# Altium schematic sheet TOP.SchDoc
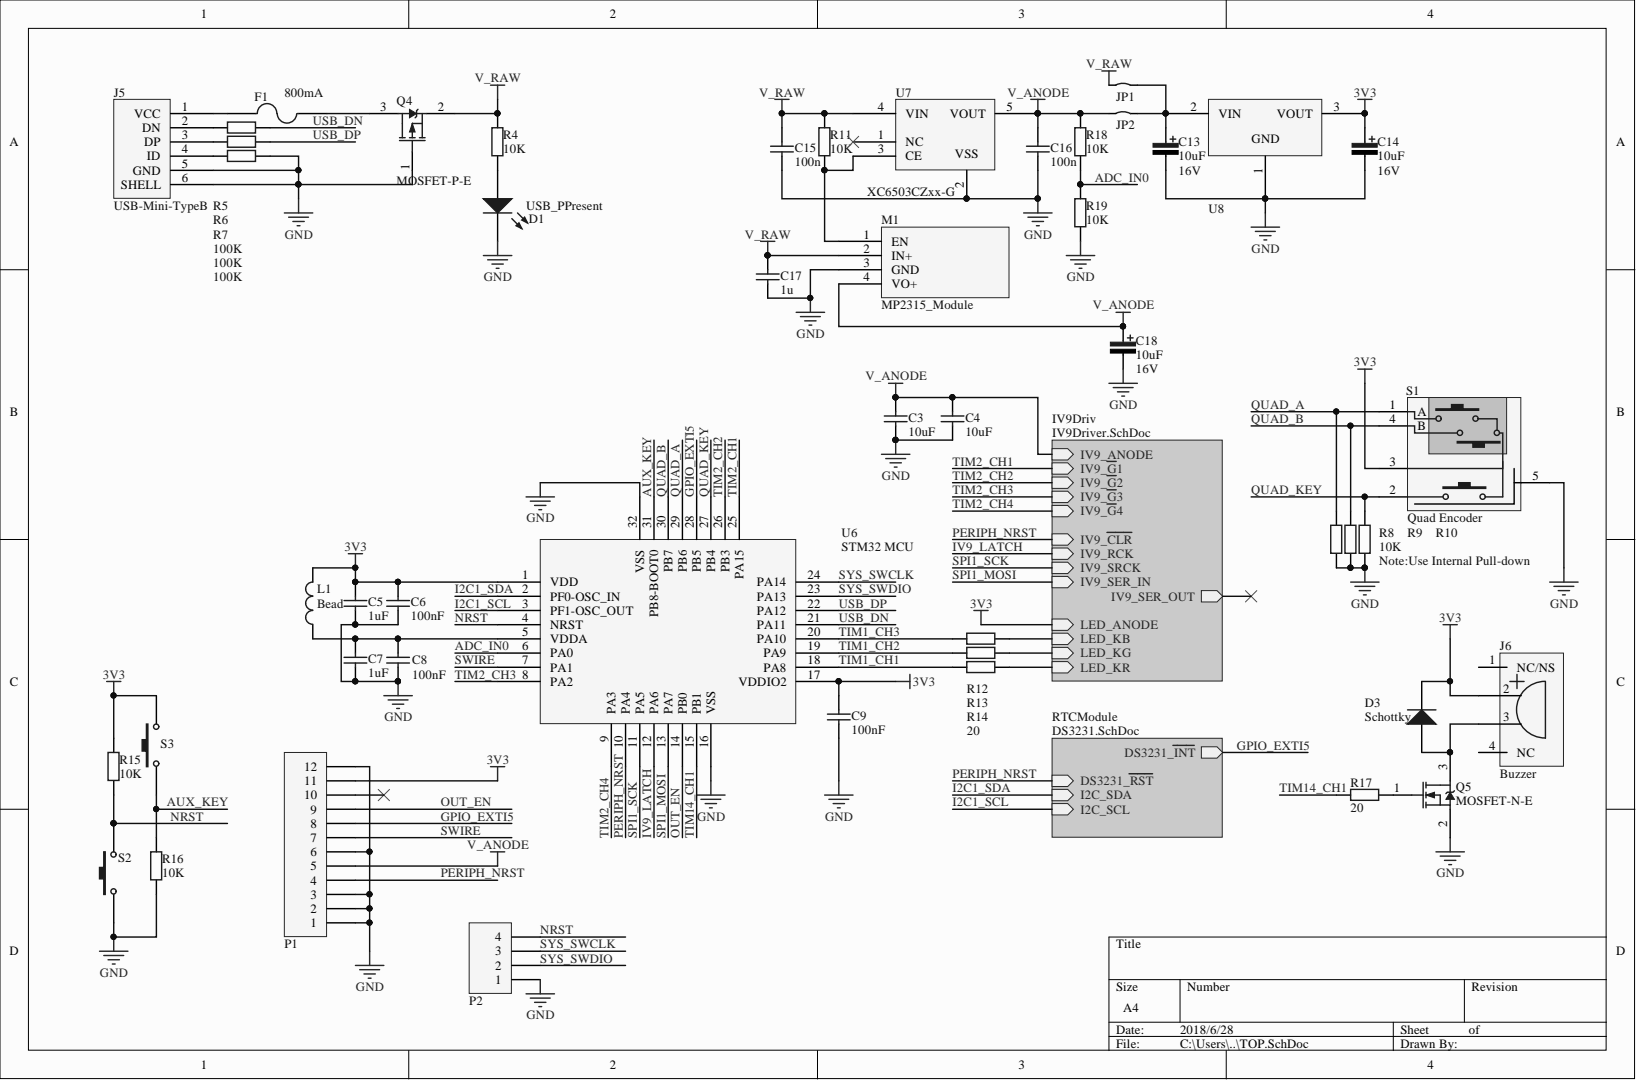
[diagram p0: TOP.SchDoc]

## Parts
C3  value 10uF  [C-RAD-0805]  (hidden comment: Cap)
C4  value 10uF  [C-RAD-0805]  (hidden comment: Cap)
C5  value 1uF  [C-RAD-0805]  (hidden comment: Cap)
C6  value 100nF  [C-RAD-0805]  (hidden comment: Cap)
C7  value 1uF  [C-RAD-0805]  (hidden comment: Cap)
C8  value 100nF  [C-RAD-0805]  (hidden comment: Cap)
C9  value 100nF  [C-RAD-0805]  (hidden comment: Cap)
C13  value 10uF  Vol: 16V  [CAP-3528]  (hidden comment: Cap Pol)
C14  value 10uF  Vol: 16V  [CAP-3528]  (hidden comment: Cap Pol)
C15  value 100n  [C-RAD-0805]  (hidden comment: Cap)
C16  value 100n  [C-RAD-0805]  (hidden comment: Cap)
C17  value 1u  [C-RAD-0805]  (hidden comment: Cap)
C18  value 10uF  Vol: 16V  [CAP-3528]  (hidden comment: Cap Pol)
D1  USB_PPresent  [LED_0805]
D3  Type: Schottky  [SOD323 - INV]  (hidden comment: Diode)
F1  value 800mA  [J1-0603]  (hidden comment: Fuse)
J5  USB-Mini-TypeB  [USB_MINI_TypeB]
J6  Buzzer  [8530S_BUZZER]
JP1  JMP  [R0402]  (value not drawn)
JP2  JMP  [R0402]  (value not drawn)
L1  value Bead  [0805-1]  (hidden comment: Inductor)
M1  MP2315_Module  [MP2315_Module]
P1  Header 12  [HDR12X1_1.27mm]  (value not drawn)
P2  Header 4  [HDR4X1_1.27mm]  (value not drawn)
Q4  MOSFET-P-E  [SOT-23]
Q5  MOSFET-N-E  [SOT-23]
R4  value 10K  [0603-1]  (hidden comment: Res1)
R5  Res1  [0603-1]  (value not drawn)
R6  Res1  [0603-1]  (value not drawn)
R7  value 100K  [0603-1]  (hidden comment: Res1)
R8  value 10K  [0603-1]  (hidden comment: Res1)
R9  Res1  [0603-1]  (value not drawn)
R10  Res1  [0603-1]  (value not drawn)
R11  value 10K  [0603-1]  (hidden comment: Res1)
R12  Res1  [0603-1]  (value not drawn)
R13  Res1  [0603-1]  (value not drawn)
R14  value 20  [0603-1]  (hidden comment: Res1)
R15  value 10K  [0603-1]  (hidden comment: Res1)
R16  value 10K  [0603-1]  (hidden comment: Res1)
R17  value 20  [0603-1]  (hidden comment: Res1)
R18  value 10K  [0603-1]  (hidden comment: Res1)
R19  value 10K  [0603-1]  (hidden comment: Res1)
S1  Quad Encoder  [SIQ-02FVS1_03_r]
S2  SW-PB  [SW_PB2_C1]  (value not drawn)
S3  SW-PB  [SW_PB2_C1]  (value not drawn)
U6  STM32 MCU  [TQFP80P900X900X160-32N]
U7  XC6503CZxx-G  [SOT-89-5]
U8  HT73XX LDO  [SOT89-150P409X160-3N]  (value not drawn)

## Nets
3V3: C5.2 C6.2 C9.2 C14.1 D3.2 J6.2 L1.2 P1.11 R15.1 S1.3 S3.1 U6.1(VDD) U6.17(VDDIO2) U8.3
GND: C3.1 C4.1 C5.1 C6.1 C7.1 C8.1 C9.1 C13.2 C14.2 C15.1 C16.1 C17.1 C18.2 D1.2 J5.5(GND) J5.6(SHELL) M1.3(GND) P1.1 P1.2 P1.3 P1.6 P1.12 P2.1 Q4.1 Q5.2 R7.1 R8.2 R9.2 R10.2 R16.2 R19.2 S1.5 S2.1 U6.16(VSS) U6.32(VSS) U7.2 U8.1
V_ANODE: C3.2 C4.2 C16.2 C18.1 JP2.1 M1.4(VO+) P1.5 R18.1 U7.5(VOUT)
V_RAW: C15.2 C17.2 JP1.1 M1.2(IN+) Q4.2 R4.1 R11.1 U7.4(VIN)
ADC_IN0: R18.2 R19.1 U6.6(PA0)
AUX_KEY: R16.1 S3.2 U6.31(PB8-BOOT0)
GPIO_EXTI5: P1.8 U6.28(PB5)
I2C1_SCL: U6.3(PF1-OSC_OUT)
I2C1_SDA: U6.2(PF0-OSC_IN)
IV9_LATCH: U6.12(PA6)
NRST: P2.4 R15.2 S2.2 U6.4(NRST)
OUT_EN: P1.9 U6.14(PB0)
PERIPH_NRST: P1.4 U6.10(PA4)
QUAD_A: R8.1 S1.1(A) U6.29(PB6)
QUAD_B: R9.1 S1.4(B) U6.30(PB7)
QUAD_KEY: R10.1 S1.2 U6.27(PB4)
SPI1_MOSI: U6.13(PA7)
SPI1_SCK: U6.11(PA5)
SWIRE: P1.7 U6.7(PA1)
SYS_SWCLK: P2.3 U6.24(PA14)
SYS_SWDIO: P2.2 U6.23(PA13)
TIM1_CH1: R14.1 U6.18(PA8)
TIM1_CH2: R13.1 U6.19(PA9)
TIM1_CH3: R12.1 U6.20(PA10)
TIM2_CH1: U6.25(PA15)
TIM2_CH2: U6.26(PB3)
TIM2_CH3: U6.8(PA2)
TIM2_CH4: U6.9(PA3)
TIM14_CH1: R17.1 U6.15(PB1)
USB_DN: R5.1 U6.21(PA11)
USB_DP: R6.1 U6.22(PA12)
NetC7_2: C7.2 C8.2 L1.1 U6.5(VDDA)
NetC13_1: C13.1 JP1.2 JP2.2 U8.2
NetD1_1: D1.1 R4.2
NetD3_1: D3.1 J6.3 Q5.3
NetF1_1: F1.1 J5.1(VCC)
NetF1_2: F1.2 Q4.3
NetJ5_2: J5.2(DN) R5.2
NetJ5_3: J5.3(DP) R6.2
NetJ5_4: J5.4(ID) R7.2
NetJ6_1: J6.1(NC/NS)
NetJ6_4: J6.4(NC)
NetM1_1: M1.1(EN) R11.2 U7.3(CE)
NetP1_10: P1.10
NetQ5_1: Q5.1 R17.2
NetR12_2: R12.2
NetR13_2: R13.2
NetR14_2: R14.2
NetU7_1: U7.1(NC)
IV9_SER_OUT:

## Library data of the parts
C3-C9 C15-C17 = Cap: 无极性电容; Component Kind: Standard; LatestRevisionDate: 17-Jul-2002; LatestRevisionNote: Re-released for DXP Platform.; PackageReference: RAD-0.3; Published: 8-Jun-2000; Library Name: fszhang.SchLib; Publisher: Altium Limited
C13 C14 C18 = Cap Pol: 极性电解电容; Component Kind: Standard; LatestRevisionDate: 17-Jul-2002; LatestRevisionNote: Re-released for DXP Platform.; PackageReference: RB7.6-15; Published: 8-Jun-2000; Library Name: fszhang.SchLib; Publisher: Altium Limited
D1 = LED0: 发光二极管; Component Kind: Standard; LatestRevisionDate: 08-Jul-2005; LatestRevisionNote: Mech Layer 1 removed.; PackageReference: LED-0; Published: 8-Jun-2000; Library Name: fszhang.SchLib; Publisher: Altium Limited
D3 = Diode: 二极管; Code_JEDEC: DO-214-AB; Component Kind: Standard; LatestRevisionDate: 17-Jul-2002; LatestRevisionNote: Re-released for DXP Platform.; PackageDocument: Sep-1996; PackageReference: SMC; Published: 8-Jun-2000; Library Name: fszhang.SchLib; Publisher: Altium Limited
F1 = Fuse 2: 保险丝; Component Kind: Standard; LatestRevisionDate: 17-Jul-2002; LatestRevisionNote: Re-released for DXP Platform.; PackageReference: PIN-W2/E2.8; Published: 8-Jun-2000; Library Name: fszhang.SchLib; Publisher: Altium Limited
J5 = USB-Mini_TypeB: Component Kind: Standard; Library Name: PrimaryLIB0.SchLib
J6 = Buzzer_8530S_SMT: Surface Mount Buzzer; Component Kind: Standard; Library Name: PrjFlora_Specific.SchLib
JP1 JP2 = JMP: Generic Jumper; Component Kind: Standard; Library Name: PrimaryLIB0.SchLib
L1 = Inductor: 电感; Code_IEC: 1005; Code_JEITA: 0402; Component Kind: Standard; LatestRevisionDate: 17-Jul-2002; LatestRevisionNote: Re-released for DXP Platform.; PackageReference: 0402-A; Published: 8-Jun-2000; Library Name: fszhang.SchLib; Publisher: Altium Limited
M1 = MP2315_Module: MP2315 3A Step-down Module; Component Kind: Standard; Library Name: GenericModules.SchLib
P1 = Header 12: 接插件; Component Kind: Standard; LatestRevisionDate: 17-Jul-2002; LatestRevisionNote: Re-released for DXP Platform.; Library Name: PrimaryLIB0.SchLib; Publisher: Altium Limited
P2 = Header 4: 接插件; Component Kind: Standard; LatestRevisionDate: 17-Jul-2002; LatestRevisionNote: Re-released for DXP Platform.; Library Name: PrimaryLIB0.SchLib; Publisher: Altium Limited
Q4 = MOSFET-P-E: P-Channel Enhanced MOSFET; PackageDocument: 1995/1996; Component Kind: Standard; LatestRevisionDate: 17-Jul-2002; LatestRevisionNote: Re-released for DXP Platform.; PackageReference: E3; Published: 8-Jun-2000; Library Name: PrimaryLIB0.SchLib; Publisher: Altium Limited
Q5 = MOSFET-N-E: N-Channel Enhanced MOSFET; PackageDocument: 1995/1996; Component Kind: Standard; LatestRevisionDate: 17-Jul-2002; LatestRevisionNote: Re-released for DXP Platform.; PackageReference: E3; Published: 8-Jun-2000; Library Name: PrimaryLIB0.SchLib; Publisher: Altium Limited
R4 R7 R8 R11 R14-R19 = Res1: 贴片电阻; Component Kind: Standard; LatestRevisionDate: 17-Jul-2002; LatestRevisionNote: Re-released for DXP Platform.; PackageReference: AXIAL-0.4; Published: 8-Jun-2000; Library Name: fszhang.SchLib; Publisher: Altium Limited
R5 R6 = Res1: 贴片电阻; Value: 100K; Component Kind: Standard; LatestRevisionDate: 17-Jul-2002; LatestRevisionNote: Re-released for DXP Platform.; PackageReference: AXIAL-0.4; Published: 8-Jun-2000; Library Name: fszhang.SchLib; Publisher: Altium Limited
R9 R10 = Res1: 贴片电阻; Value: 10K; Component Kind: Standard; LatestRevisionDate: 17-Jul-2002; LatestRevisionNote: Re-released for DXP Platform.; PackageReference: AXIAL-0.4; Published: 8-Jun-2000; Library Name: fszhang.SchLib; Publisher: Altium Limited
R12 R13 = Res1: 贴片电阻; Value: 20; Component Kind: Standard; LatestRevisionDate: 17-Jul-2002; LatestRevisionNote: Re-released for DXP Platform.; PackageReference: AXIAL-0.4; Published: 8-Jun-2000; Library Name: fszhang.SchLib; Publisher: Altium Limited
S1 = SIQ_02FVS1: Slide and Rotary Encoder Switches; Component Kind: Standard; Library Name: PrjFlora_Specific.SchLib
S2 S3 = SW-PB: 微动开关; Component Kind: Standard; LatestRevisionDate: 17-Jul-2002; LatestRevisionNote: Re-released for DXP Platform.; PackageReference: SPST-2; Published: 24-Mar-1999; Library Name: fszhang.SchLib; Publisher: Altium Limited
U6 = STM32F042K6T6: ARM®-based 32-bit MCU, up to 32 KB Flash, crystal-less USB FS 2.0, CAN, 9 timers, ADC and comm. interfaces, 2.0 - 3.6 V; Component Kind: Standard; Library Name: PrjFlora_Specific.SchLib
U7 = XC6503: TOREX CL Capacitor-Less 500mA Low Power Consumption High Speed LDO Regulator; Component Kind: Standard; Library Name: PrimaryLIB0.SchLib
U8 = HT73xx: HOLTEK Low Power Consumption LDO; Component Kind: Standard; Library Name: PrimaryLIB0.SchLib
